# Altium schematic sheet lv_io_retro.SchDoc
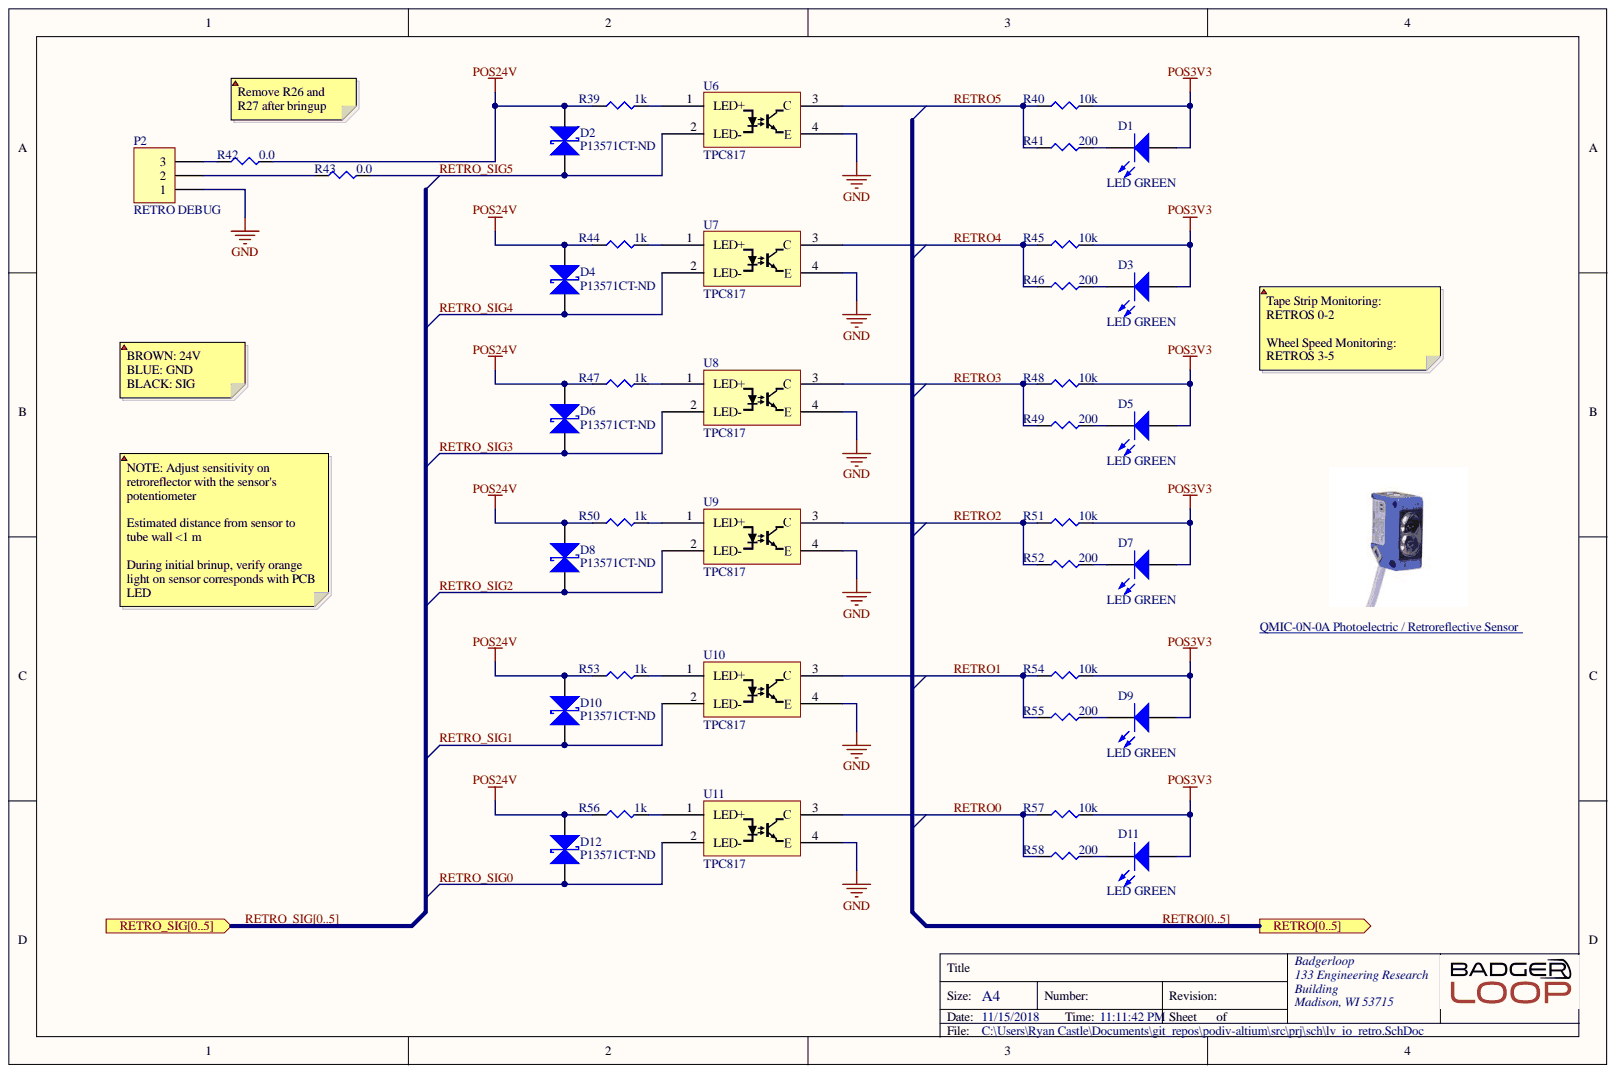
[diagram p0: lv_io_retro.SchDoc]

## Parts
D1  LED GREEN  Color: GREEN  [LED 0603 GREEN]
D2  Digikey P/N: P13571CT-ND  [SMD-0402-RES]  (hidden comment: ESD Diode)
D3  LED GREEN  Color: GREEN  [LED 0603 GREEN]
D4  Digikey P/N: P13571CT-ND  [SMD-0402-RES]  (hidden comment: ESD Diode)
D5  LED GREEN  Color: GREEN  [LED 0603 GREEN]
D6  Digikey P/N: P13571CT-ND  [SMD-0402-RES]  (hidden comment: ESD Diode)
D7  LED GREEN  Color: GREEN  [LED 0603 GREEN]
D8  Digikey P/N: P13571CT-ND  [SMD-0402-RES]  (hidden comment: ESD Diode)
D9  LED GREEN  Color: GREEN  [LED 0603 GREEN]
D10  Digikey P/N: P13571CT-ND  [SMD-0402-RES]  (hidden comment: ESD Diode)
D11  LED GREEN  Color: GREEN  [LED 0603 GREEN]
D12  Digikey P/N: P13571CT-ND  [SMD-0402-RES]  (hidden comment: ESD Diode)
P2  RETRO DEBUG  [Pin Header 1x3 TH Pitch 2.54mm]
R39  1k  [SMD-0603-RES]
R40  10k  [SMD-0603-RES]
R41  200  [SMD-0603-RES]
R42  0.0  [SMD-0402-RES]
R43  0.0  [SMD-0402-RES]
R44  1k  [SMD-0603-RES]
R45  10k  [SMD-0603-RES]
R46  200  [SMD-0603-RES]
R47  1k  [SMD-0603-RES]
R48  10k  [SMD-0603-RES]
R49  200  [SMD-0603-RES]
R50  1k  [SMD-0603-RES]
R51  10k  [SMD-0603-RES]
R52  200  [SMD-0603-RES]
R53  1k  [SMD-0603-RES]
R54  10k  [SMD-0603-RES]
R55  200  [SMD-0603-RES]
R56  1k  [SMD-0603-RES]
R57  10k  [SMD-0603-RES]
R58  200  [SMD-0603-RES]
U6  TPC817
U7  TPC817
U8  TPC817
U9  TPC817
U10  TPC817
U11  TPC817

## Ports
RETRO0, RETRO1, RETRO2, RETRO3, RETRO4, RETRO5, RETRO[0..5], RETRO_SIG0, RETRO_SIG1, RETRO_SIG2, RETRO_SIG3, RETRO_SIG4, RETRO_SIG5, RETRO_SIG[0..5]

## Nets
GND: P2.1 U6.4(E) U7.4(E) U8.4(E) U9.4(E) U10.4(E) U11.4(E)
POS3V3: D1.1 D3.1 D5.1 D7.1 D9.1 D11.1 R40.2 R45.2 R48.2 R51.2 R54.2 R57.2
POS24V: D2.2 D4.2 D6.2 D8.2 D10.2 D12.2 R39.1 R42.2 R44.1 R47.1 R50.1 R53.1 R56.1
RETRO0: R57.1 R58.1 U11.3(C) ; port RETRO[0..5]  [also labelled RETRO]
RETRO1: R54.1 R55.1 U10.3(C) ; port RETRO[0..5]  [also labelled RETRO]
RETRO2: R51.1 R52.1 U9.3(C) ; port RETRO[0..5]  [also labelled RETRO]
RETRO3: R48.1 R49.1 U8.3(C) ; port RETRO[0..5]  [also labelled RETRO]
RETRO4: R45.1 R46.1 U7.3(C) ; port RETRO[0..5]  [also labelled RETRO]
RETRO5: R40.1 R41.1 U6.3(C) ; port RETRO[0..5]  [also labelled RETRO]
RETRO_SIG0: D12.1 U11.2(LED-) ; port RETRO_SIG[0..5]  [also labelled RETRO_SIG]
RETRO_SIG1: D10.1 U10.2(LED-) ; port RETRO_SIG[0..5]  [also labelled RETRO_SIG]
RETRO_SIG2: D8.1 U9.2(LED-) ; port RETRO_SIG[0..5]  [also labelled RETRO_SIG]
RETRO_SIG3: D6.1 U8.2(LED-) ; port RETRO_SIG[0..5]  [also labelled RETRO_SIG]
RETRO_SIG4: D4.1 U7.2(LED-) ; port RETRO_SIG[0..5]  [also labelled RETRO_SIG]
RETRO_SIG5: D2.1 R43.2 U6.2(LED-) ; port RETRO_SIG[0..5]  [also labelled RETRO_SIG]
NetD1_2: D1.2 R41.2
NetD3_2: D3.2 R46.2
NetD5_2: D5.2 R49.2
NetD7_2: D7.2 R52.2
NetD9_2: D9.2 R55.2
NetD11_2: D11.2 R58.2
NetP2_2: P2.2 R43.1
NetP2_3: P2.3 R42.1
NetR39_2: R39.2 U6.1(LED+)
NetR44_2: R44.2 U7.1(LED+)
NetR47_2: R47.2 U8.1(LED+)
NetR50_2: R50.2 U9.1(LED+)
NetR53_2: R53.2 U10.1(LED+)
NetR56_2: R56.2 U11.1(LED+)

## Library data of the parts
D1 D3 D5 D7 D9 D11 = LED GREEN 0603: Manufacturer: Lite-On Inc.; Manufacturer P/N: LTST-C190KGKT; Component Kind: Standard; Digikey P/N: 160-1435-1-ND; Library Name: misc.SchLib; Package / Case: 0603
D2 D4 D6 D8 D10 D12 = ESD Diode: ESD Supressor; Manufacturer: EZAEG; Manufacturer P/N: Panasonic; Component Kind: Standard; Digikey Link: https://www.digikey.com/product-detail/en/panasonic-electronic-components/EZA-EG2A50AX/P13571CT-ND/1137239; Library Name: misc.SchLib
P2 = Header 3: Header, 3-Pin; Component Kind: Standard; LatestRevisionDate: 17-Jul-2002; LatestRevisionNote: Re-released for DXP Platform.; Library Name: connector.SchLib; Signal Integrity: Connector; Publisher: Altium Limited
R39 R44 R47 R50 R53 R56 = ERJ-P03F1001V: RES SMD 1K OHM 1% 1/5W 0603; Manufacturer: Panasonic Electronic Components; @ qty: 1500; Factory Stock: 0; Minimum Quantity: 1; Power (Watts): 0.2W, 1/5W; Temperature Coefficient: ±200ppm/°C; Unit Price (USD): 0.05227; Component Kind: Standard; Composition: Thick Film; Datasheets: https://industrial.panasonic.com/cdbs/www-data/pdf/RDA0000/AOA0000C243.pdf; Digi-Key Part Number: P16018CT-ND; Failure Rate: -; Features: Automotive AEC-Q200, Pulse Withstanding; Height - Seated (Max): 0.022" (0.55mm); Image: //media.digikey.com/Photos/Panasonic%20Photos/MFG_ERJ-P6W%20Series.jpg; Library Name: resistor.SchLib; Number of Terminations: 2; Operating Temperature: -55°C ~ 155°C; Package / Case: 0603 (1608 Metric); Packaging: Cut Tape (CT); Part Number: ERJ-P03F1001V; Part Status: Active; Quantity Available: 3331; Series: ERJ; Size / Dimension: 0.063" L x 0.031" W (1.60mm x 0.80mm); Supplier Device Package: 0603; Tolerance: ±1%; Value: 1k
R40 R45 R48 R51 R54 R57 = ERJ-P03J103V: RES SMD 10K OHM 5% 1/5W 0603; Manufacturer: Panasonic Electronic Components; @ qty: 1500; Factory Stock: 0; Minimum Quantity: 1; Power (Watts): 0.2W, 1/5W; Temperature Coefficient: ±200ppm/°C; Unit Price (USD): 0.03584; Component Kind: Standard; Composition: Thick Film; Datasheets: https://industrial.panasonic.com/cdbs/www-data/pdf/RDA0000/AOA0000C243.pdf; Digi-Key Part Number: P16032CT-ND; Failure Rate: -; Features: Automotive AEC-Q200, Pulse Withstanding; Height - Seated (Max): 0.022" (0.55mm); Image: //media.digikey.com/Photos/Panasonic%20Photos/MFG_ERJ-P6W%20Series.jpg; Library Name: resistor.SchLib; Number of Terminations: 2; Operating Temperature: -55°C ~ 155°C; Package / Case: 0603 (1608 Metric); Packaging: Cut Tape (CT); Part Number: ERJ-P03J103V; Part Status: Active; Quantity Available: 857; Series: ERJ; Size / Dimension: 0.063" L x 0.031" W (1.60mm x 0.80mm); Supplier Device Package: 0603; Tolerance: ±5%; Value: 10k
R41 R46 R49 R52 R55 R58 = ERJ-P03J201V: RES SMD 200 OHM 5% 1/5W 0603; Manufacturer: Panasonic Electronic Components; @ qty: 1500; Factory Stock: 0; Minimum Quantity: 1; Power (Watts): 0.2W, 1/5W; Temperature Coefficient: ±200ppm/°C; Unit Price (USD): 0.03584; Component Kind: Standard; Composition: Thick Film; Datasheets: https://industrial.panasonic.com/cdbs/www-data/pdf/RDA0000/AOA0000C243.pdf; Digi-Key Part Number: P16033CT-ND; Failure Rate: -; Features: Automotive AEC-Q200, Pulse Withstanding; Height - Seated (Max): 0.022" (0.55mm); Image: //media.digikey.com/Photos/Panasonic%20Photos/MFG_ERJ-P6W%20Series.jpg; Library Name: resistor.SchLib; Number of Terminations: 2; Operating Temperature: -55°C ~ 155°C; Package / Case: 0603 (1608 Metric); Packaging: Cut Tape (CT); Part Number: ERJ-P03J201V; Part Status: Active; Quantity Available: 6803; Series: ERJ; Size / Dimension: 0.063" L x 0.031" W (1.60mm x 0.80mm); Supplier Device Package: 0603; Tolerance: ±5%; Value: 200
R42 R43 = ERJ-2GE0R00X: RES SMD 0.0OHM JUMPER 1/10W 0402; Manufacturer: Panasonic Electronic Components; @ qty: 1500; Factory Stock: 0; Minimum Quantity: 1; Power (Watts): 0.1W, 1/10W; Unit Price (USD): 0.00384; Component Kind: Standard; Composition: Thick Film; Datasheets: http://industrial.panasonic.com/www-cgi/jvcr13pz.cgi?E+PZ+3+AOA0001+ERJ2GE0R00X+7+WW; Digi-Key Part Number: P0.0JCT-ND; Failure Rate: -; Features: Automotive AEC-Q200; Height - Seated (Max): 0.016" (0.40mm); Image: //media.digikey.com/Photos/Panasonic%20Photos/ERJ-PA2-Series.jpg; Library Name: resistor.SchLib; Number of Terminations: 2; Operating Temperature: -55°C ~ 155°C; Package / Case: 0402 (1005 Metric); Packaging: Cut Tape (CT); Part Number: ERJ-2GE0R00X; Part Status: Active; Quantity Available: 3152483; Series: ERJ; Size / Dimension: 0.039" L x 0.020" W (1.00mm x 0.50mm); Supplier Device Package: 0402; Temperature Coefficient: -; Tolerance: Jumper; Value: 0.0
U6-U11 = TPC817: Component Kind: Standard; Library Name: temp.SCHLIB
